# Altium schematic sheet Power%20Board%20Roberto%201.0.SchDoc
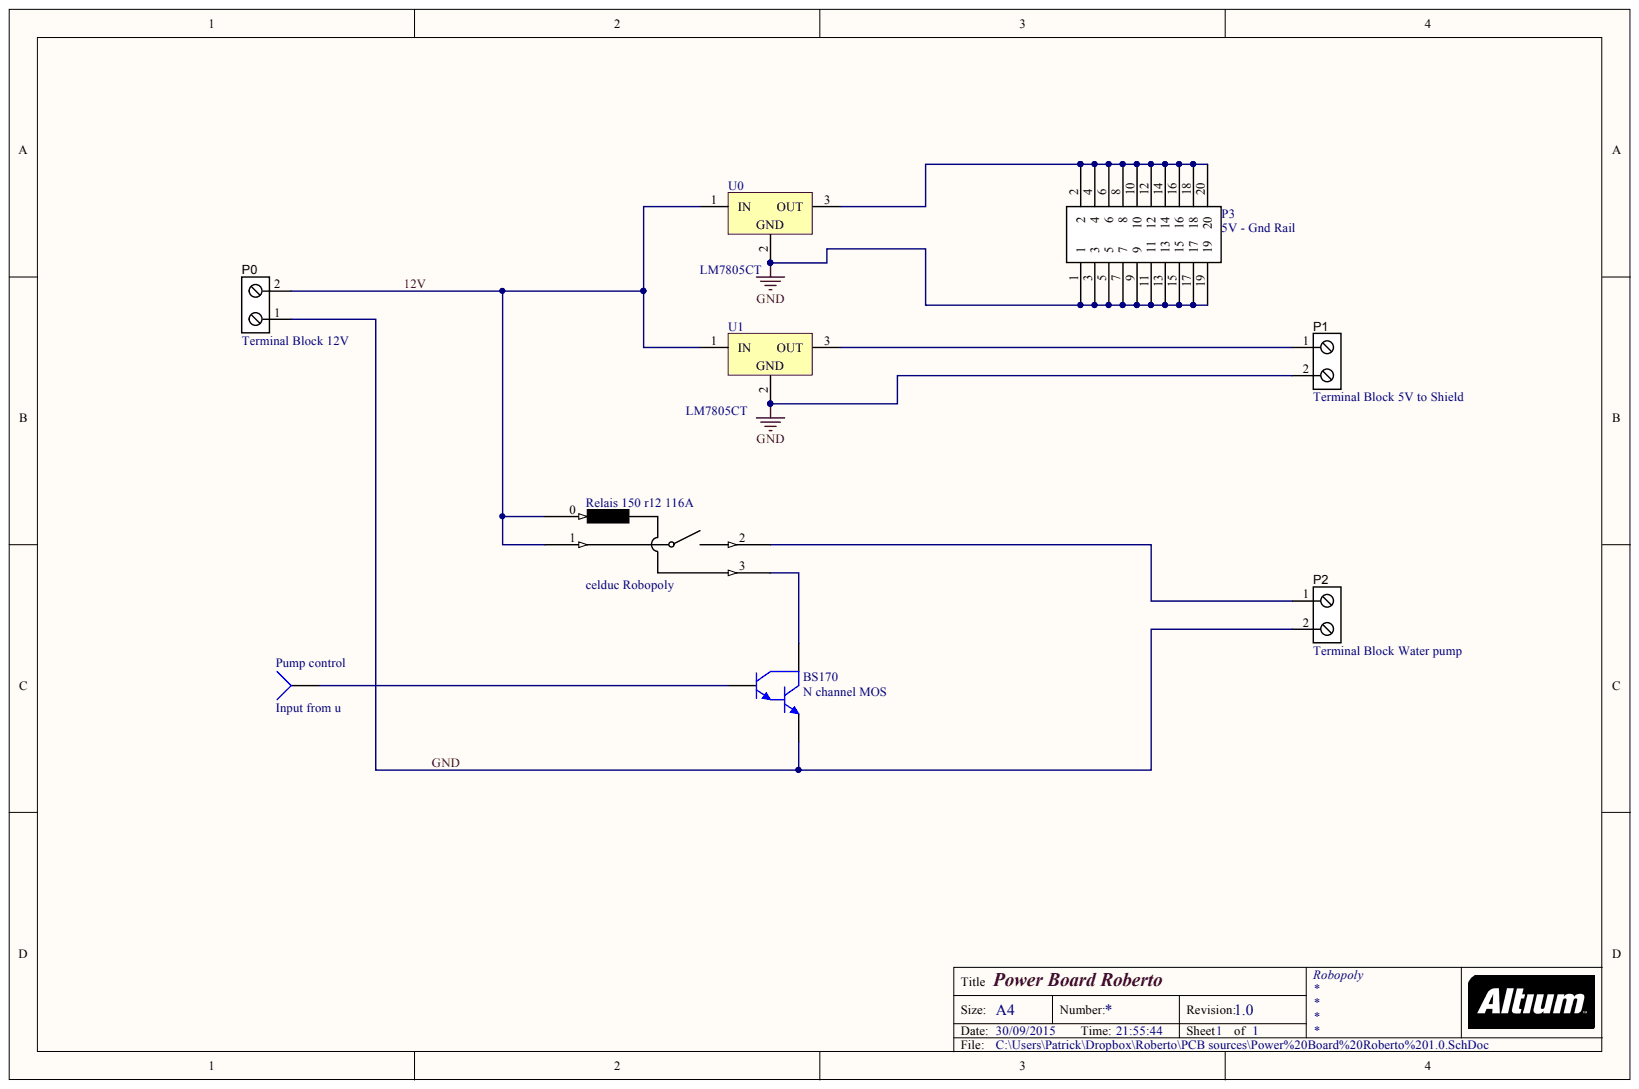
[diagram p0: Power%20Board%20Roberto%201.0.SchDoc]

## Parts
BS170  N channel MOS  [TO-92]
P0  Terminal Block 12V  [Phoenix PT 2-Pole]
P1  Terminal Block 5V to Shield  [Phoenix PT 2-Pole]
P2  Terminal Block Water pump  [Phoenix PT 2-Pole]
P3  5V - Gnd Rail  [HEADER 10x2]
Pump_control  Input from u  [PIN1]
Relais_150_r12_116A  celduc Robopoly  [Relais 150 r12 116A]
U0  LM7805CT  [T03B]
U1  LM7805CT  [T03B]

## Nets
12V: P0.2 Relais_150_r12_116A.0 Relais_150_r12_116A.1 U0.1 U1.1
GND: BS170.3 P0.1 P1.2 P2.2 P3.1 P3.3 P3.5 P3.7 P3.9 P3.11 P3.13 P3.15 P3.17 P3.19 U0.2 U1.2
NetBS170_1: BS170.1 Relais_150_r12_116A.3
NetBS170_2: BS170.2 Pump_control.1
NetP1_1: P1.1 U1.3
NetP2_1: P2.1 Relais_150_r12_116A.2
NetP3_2: P3.2 P3.4 P3.6 P3.8 P3.10 P3.12 P3.14 P3.16 P3.18 P3.20 U0.3

## Library data of the parts
BS170 = NPN1: N channel MOSfet; Code_JEDEC: TO-92; Component Kind: Standard; DatasheetVersion: 1989; LatestRevisionDate: 17-Jul-2002; LatestRevisionNote: Re-released for DXP Platform.; Library Name: Miscellaneous Devices.IntLib; PackageDescription: TO, Flat Index; 3 In-Line, Axial Leads; Body Dia. 4.6mm; Leads 0.41 x 0.39 mm (max); PackageReference: TO-92; PackageVersion: 1989; Published: 8-Jun-2000; Signal Integrity: NPN; Simulation: NPN1; Publisher: Altium Limited
P0-P2 = Screw Block 2: 2-Pin Screw Terminal; Component Kind: Standard; LatestRevisionDate: 17-Jul-2002; LatestRevisionNote: Re-released for DXP Platform.; Library Name: andylib.SchLib; Publisher: Altium Limited
P3 = HEADER 10x2: Header, 2-Pin; Component Kind: Standard; LatestRevisionDate: 17-Jul-2002; LatestRevisionNote: Re-released for DXP Platform.; Signal Integrity: Connector; Library Name: marcolib.SchLib; Publisher: Altium Limited
Pump_control = Socket: Component Kind: Standard; Description: Socket; LatestRevisionDate: 17-Jul-2002; LatestRevisionNote: Re-released for DXP Platform.; Library Name: Miscellaneous Connectors.IntLib; Signal Integrity: Connector; Publisher: Altium Limited
Relais_150_r12_116A = Relai celduc Robopoly: Relais; Component Kind: Standard; Library Name: benjaminlib.SchLib
U0 U1 = LM7808CT: Series 3-Terminal Positive Regulators, 3-pin TO-220; Manufacturer: National Semiconductor; CompType: LDO IC; PackageTopMarking: NSUZXYTTE#*LM7808CT; Component Kind: Standard; ComponentLink1Description: LM340 Datasheet; ComponentLink1URL: http://www.national.com/ds/LM/LM340.pdf; ComponentLink2Description: LM340 Web Page; ComponentLink2URL: http://www.national.com/pf/LM/LM340.html; ComponentLink3Description: T03B Package Page; ComponentLink3URL: http://www.national.com/packaging/folders/t03b.html; DatasheetVersion: Jul-2006; Library Name: NSC Legacy.IntLib; PackageDescription: TO-220, Molded, 3 Lead, Straight; PackageReference: T03B; PackageVersion: revL, Jan-1992; PartNumber: LM7808CT; PinCount: 3; Published: 4-Dec-2008; RoHS: O; Supplier 1: Farnell; Supplier Part Number 1: 9490248; Publisher: Altium Limited
